# Altium schematic sheet Leds.SchDoc
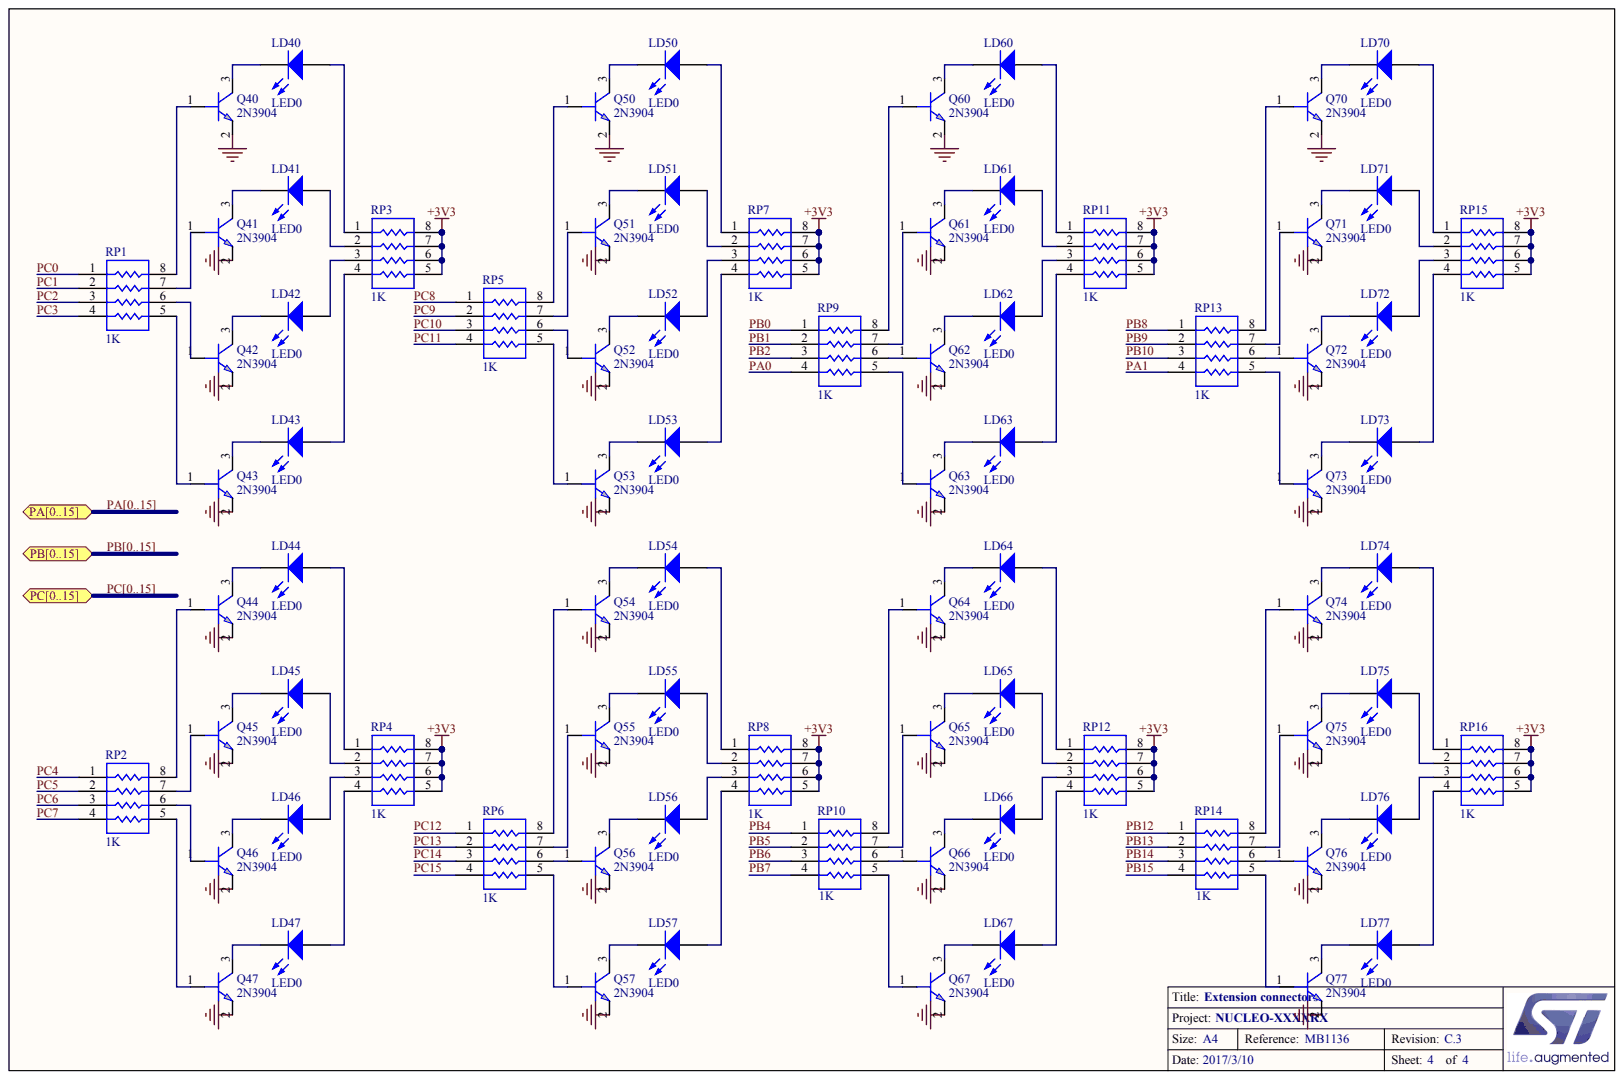
[diagram p0: Leds.SchDoc]

## Parts
LD40  LED0
LD41  LED0
LD42  LED0
LD43  LED0
LD44  LED0
LD45  LED0
LD46  LED0
LD47  LED0
LD50  LED0
LD51  LED0
LD52  LED0
LD53  LED0
LD54  LED0
LD55  LED0
LD56  LED0
LD57  LED0
LD60  LED0
LD61  LED0
LD62  LED0
LD63  LED0
LD64  LED0
LD65  LED0
LD66  LED0
LD67  LED0
LD70  LED0
LD71  LED0
LD72  LED0
LD73  LED0
LD74  LED0
LD75  LED0
LD76  LED0
LD77  LED0
Q40  2N3904
Q41  2N3904
Q42  2N3904
Q43  2N3904
Q44  2N3904
Q45  2N3904
Q46  2N3904
Q47  2N3904
Q50  2N3904
Q51  2N3904
Q52  2N3904
Q53  2N3904
Q54  2N3904
Q55  2N3904
Q56  2N3904
Q57  2N3904
Q60  2N3904
Q61  2N3904
Q62  2N3904
Q63  2N3904
Q64  2N3904
Q65  2N3904
Q66  2N3904
Q67  2N3904
Q70  2N3904
Q71  2N3904
Q72  2N3904
Q73  2N3904
Q74  2N3904
Q75  2N3904
Q76  2N3904
Q77  2N3904
RP1  1K
RP2  1K
RP3  1K
RP4  1K
RP5  1K
RP6  1K
RP7  1K
RP8  1K
RP9  1K
RP10  1K
RP11  1K
RP12  1K
RP13  1K
RP14  1K
RP15  1K
RP16  1K

## Ports
PA0, PA1, PA2, PA3, PA4, PA5, PA6, PA7, PA8, PA9, PA10, PA11, PA12, PA13, PA14, PA15, PA[0..15], PB0, PB1, PB2, PB3, PB4, PB5, PB6, PB7, PB8, PB9, PB10, PB11, PB12, PB13, PB14, PB15, PB[0..15], PC0, PC1, PC2, PC3, PC4, PC5, PC6, PC7, PC8, PC9, PC10, PC11, PC12, PC13, PC14, PC15, PC[0..15]

## Nets
+3V3: RP3.5 RP3.6 RP3.7 RP3.8 RP4.5 RP4.6 RP4.7 RP4.8 RP7.5 RP7.6 RP7.7 RP7.8 RP8.5 RP8.6 RP8.7 RP8.8 RP11.5 RP11.6 RP11.7 RP11.8 RP12.5 RP12.6 RP12.7 RP12.8 RP15.5 RP15.6 RP15.7 RP15.8 RP16.5 RP16.6 RP16.7 RP16.8
GND: Q40.2 Q41.2 Q42.2 Q43.2 Q44.2 Q45.2 Q46.2 Q47.2 Q50.2 Q51.2 Q52.2 Q53.2 Q54.2 Q55.2 Q56.2 Q57.2 Q60.2 Q61.2 Q62.2 Q63.2 Q64.2 Q65.2 Q66.2 Q67.2 Q70.2 Q71.2 Q72.2 Q73.2 Q74.2 Q75.2 Q76.2 Q77.2
PA0: RP9.4 ; port PA[0..15]  [also labelled PA]
PA1: RP13.4 ; port PA[0..15]  [also labelled PA]
PB0: RP9.1 ; port PB[0..15]  [also labelled PB]
PB1: RP9.2 ; port PB[0..15]  [also labelled PB]
PB2: RP9.3 ; port PB[0..15]  [also labelled PB]
PB4: RP10.1 ; port PB[0..15]  [also labelled PB]
PB5: RP10.2 ; port PB[0..15]  [also labelled PB]
PB6: RP10.3 ; port PB[0..15]  [also labelled PB]
PB7: RP10.4 ; port PB[0..15]  [also labelled PB]
PB8: RP13.1 ; port PB[0..15]  [also labelled PB]
PB9: RP13.2 ; port PB[0..15]  [also labelled PB]
PB10: RP13.3 ; port PB[0..15]  [also labelled PB]
PB12: RP14.1 ; port PB[0..15]  [also labelled PB]
PB13: RP14.2 ; port PB[0..15]  [also labelled PB]
PB14: RP14.3 ; port PB[0..15]  [also labelled PB]
PB15: RP14.4 ; port PB[0..15]  [also labelled PB]
PC0: RP1.1 ; port PC[0..15]  [also labelled PC]
PC1: RP1.2 ; port PC[0..15]  [also labelled PC]
PC2: RP1.3 ; port PC[0..15]  [also labelled PC]
PC3: RP1.4 ; port PC[0..15]  [also labelled PC]
PC4: RP2.1 ; port PC[0..15]  [also labelled PC]
PC5: RP2.2 ; port PC[0..15]  [also labelled PC]
PC6: RP2.3 ; port PC[0..15]  [also labelled PC]
PC7: RP2.4 ; port PC[0..15]  [also labelled PC]
PC8: RP5.1 ; port PC[0..15]  [also labelled PC]
PC9: RP5.2 ; port PC[0..15]  [also labelled PC]
PC10: RP5.3 ; port PC[0..15]  [also labelled PC]
PC11: RP5.4 ; port PC[0..15]  [also labelled PC]
PC12: RP6.1 ; port PC[0..15]  [also labelled PC]
PC13: RP6.2 ; port PC[0..15]  [also labelled PC]
PC14: RP6.3 ; port PC[0..15]  [also labelled PC]
PC15: RP6.4 ; port PC[0..15]  [also labelled PC]
NetLD40_1: LD40.1 RP3.1
NetLD40_2: LD40.2 Q40.3
NetLD41_1: LD41.1 RP3.2
NetLD41_2: LD41.2 Q41.3
NetLD42_1: LD42.1 RP3.3
NetLD42_2: LD42.2 Q42.3
NetLD43_1: LD43.1 RP3.4
NetLD43_2: LD43.2 Q43.3
NetLD44_1: LD44.1 RP4.1
NetLD44_2: LD44.2 Q44.3
NetLD45_1: LD45.1 RP4.2
NetLD45_2: LD45.2 Q45.3
NetLD46_1: LD46.1 RP4.3
NetLD46_2: LD46.2 Q46.3
NetLD47_1: LD47.1 RP4.4
NetLD47_2: LD47.2 Q47.3
NetLD50_1: LD50.1 RP7.1
NetLD50_2: LD50.2 Q50.3
NetLD51_1: LD51.1 RP7.2
NetLD51_2: LD51.2 Q51.3
NetLD52_1: LD52.1 RP7.3
NetLD52_2: LD52.2 Q52.3
NetLD53_1: LD53.1 RP7.4
NetLD53_2: LD53.2 Q53.3
NetLD54_1: LD54.1 RP8.1
NetLD54_2: LD54.2 Q54.3
NetLD55_1: LD55.1 RP8.2
NetLD55_2: LD55.2 Q55.3
NetLD56_1: LD56.1 RP8.3
NetLD56_2: LD56.2 Q56.3
NetLD57_1: LD57.1 RP8.4
NetLD57_2: LD57.2 Q57.3
NetLD60_1: LD60.1 RP11.1
NetLD60_2: LD60.2 Q60.3
NetLD61_1: LD61.1 RP11.2
NetLD61_2: LD61.2 Q61.3
NetLD62_1: LD62.1 RP11.3
NetLD62_2: LD62.2 Q62.3
NetLD63_1: LD63.1 RP11.4
NetLD63_2: LD63.2 Q63.3
NetLD64_1: LD64.1 RP12.1
NetLD64_2: LD64.2 Q64.3
NetLD65_1: LD65.1 RP12.2
NetLD65_2: LD65.2 Q65.3
NetLD66_1: LD66.1 RP12.3
NetLD66_2: LD66.2 Q66.3
NetLD67_1: LD67.1 RP12.4
NetLD67_2: LD67.2 Q67.3
NetLD70_1: LD70.1 RP15.1
NetLD70_2: LD70.2 Q70.3
NetLD71_1: LD71.1 RP15.2
NetLD71_2: LD71.2 Q71.3
NetLD72_1: LD72.1 RP15.3
NetLD72_2: LD72.2 Q72.3
NetLD73_1: LD73.1 RP15.4
NetLD73_2: LD73.2 Q73.3
NetLD74_1: LD74.1 RP16.1
NetLD74_2: LD74.2 Q74.3
NetLD75_1: LD75.1 RP16.2
NetLD75_2: LD75.2 Q75.3
NetLD76_1: LD76.1 RP16.3
NetLD76_2: LD76.2 Q76.3
NetLD77_1: LD77.1 RP16.4
NetLD77_2: LD77.2 Q77.3
NetQ40_1: Q40.1 RP1.8
NetQ41_1: Q41.1 RP1.7
NetQ42_1: Q42.1 RP1.6
NetQ43_1: Q43.1 RP1.5
NetQ44_1: Q44.1 RP2.8
NetQ45_1: Q45.1 RP2.7
NetQ46_1: Q46.1 RP2.6
NetQ47_1: Q47.1 RP2.5
NetQ50_1: Q50.1 RP5.8
NetQ51_1: Q51.1 RP5.7
NetQ52_1: Q52.1 RP5.6
NetQ53_1: Q53.1 RP5.5
NetQ54_1: Q54.1 RP6.8
NetQ55_1: Q55.1 RP6.7
NetQ56_1: Q56.1 RP6.6
NetQ57_1: Q57.1 RP6.5
NetQ60_1: Q60.1 RP9.8
NetQ61_1: Q61.1 RP9.7
NetQ62_1: Q62.1 RP9.6
NetQ63_1: Q63.1 RP9.5
NetQ64_1: Q64.1 RP10.8
NetQ65_1: Q65.1 RP10.7
NetQ66_1: Q66.1 RP10.6
NetQ67_1: Q67.1 RP10.5
NetQ70_1: Q70.1 RP13.8
NetQ71_1: Q71.1 RP13.7
NetQ72_1: Q72.1 RP13.6
NetQ73_1: Q73.1 RP13.5
NetQ74_1: Q74.1 RP14.8
NetQ75_1: Q75.1 RP14.7
NetQ76_1: Q76.1 RP14.6
NetQ77_1: Q77.1 RP14.5
PA2: ; port PA[0..15]  [also labelled PA]
PA3: ; port PA[0..15]  [also labelled PA]
PA4: ; port PA[0..15]  [also labelled PA]
PA5: ; port PA[0..15]  [also labelled PA]
PA6: ; port PA[0..15]  [also labelled PA]
PA7: ; port PA[0..15]  [also labelled PA]
PA8: ; port PA[0..15]  [also labelled PA]
PA9: ; port PA[0..15]  [also labelled PA]
PA10: ; port PA[0..15]  [also labelled PA]
PA11: ; port PA[0..15]  [also labelled PA]
PA12: ; port PA[0..15]  [also labelled PA]
PA13: ; port PA[0..15]  [also labelled PA]
PA14: ; port PA[0..15]  [also labelled PA]
PA15: ; port PA[0..15]  [also labelled PA]
PB3: ; port PB[0..15]  [also labelled PB]
PB11: ; port PB[0..15]  [also labelled PB]

## Library data of the parts
Q40-Q47 Q50-Q57 Q60-Q67 Q70-Q77 = (no symbol name): Text Field1: 20; Text Field2: 20
LD40-LD47 LD50-LD57 LD60-LD67 LD70-LD77 RP1-RP16 = (no symbol name)
  LD40-LD47 LD50-LD57 LD60-LD67 LD70-LD77: Published: 8-Jun-2000; LatestRevisionDate: 08-Jul-2005; LatestRevisionNote: Mech Layer 1 removed.; PackageReference: LED-0; PackageDescription: LED; 2 Leads; Publisher: Altium Limited
